# Altium schematic sheet Sheet1.SchDoc
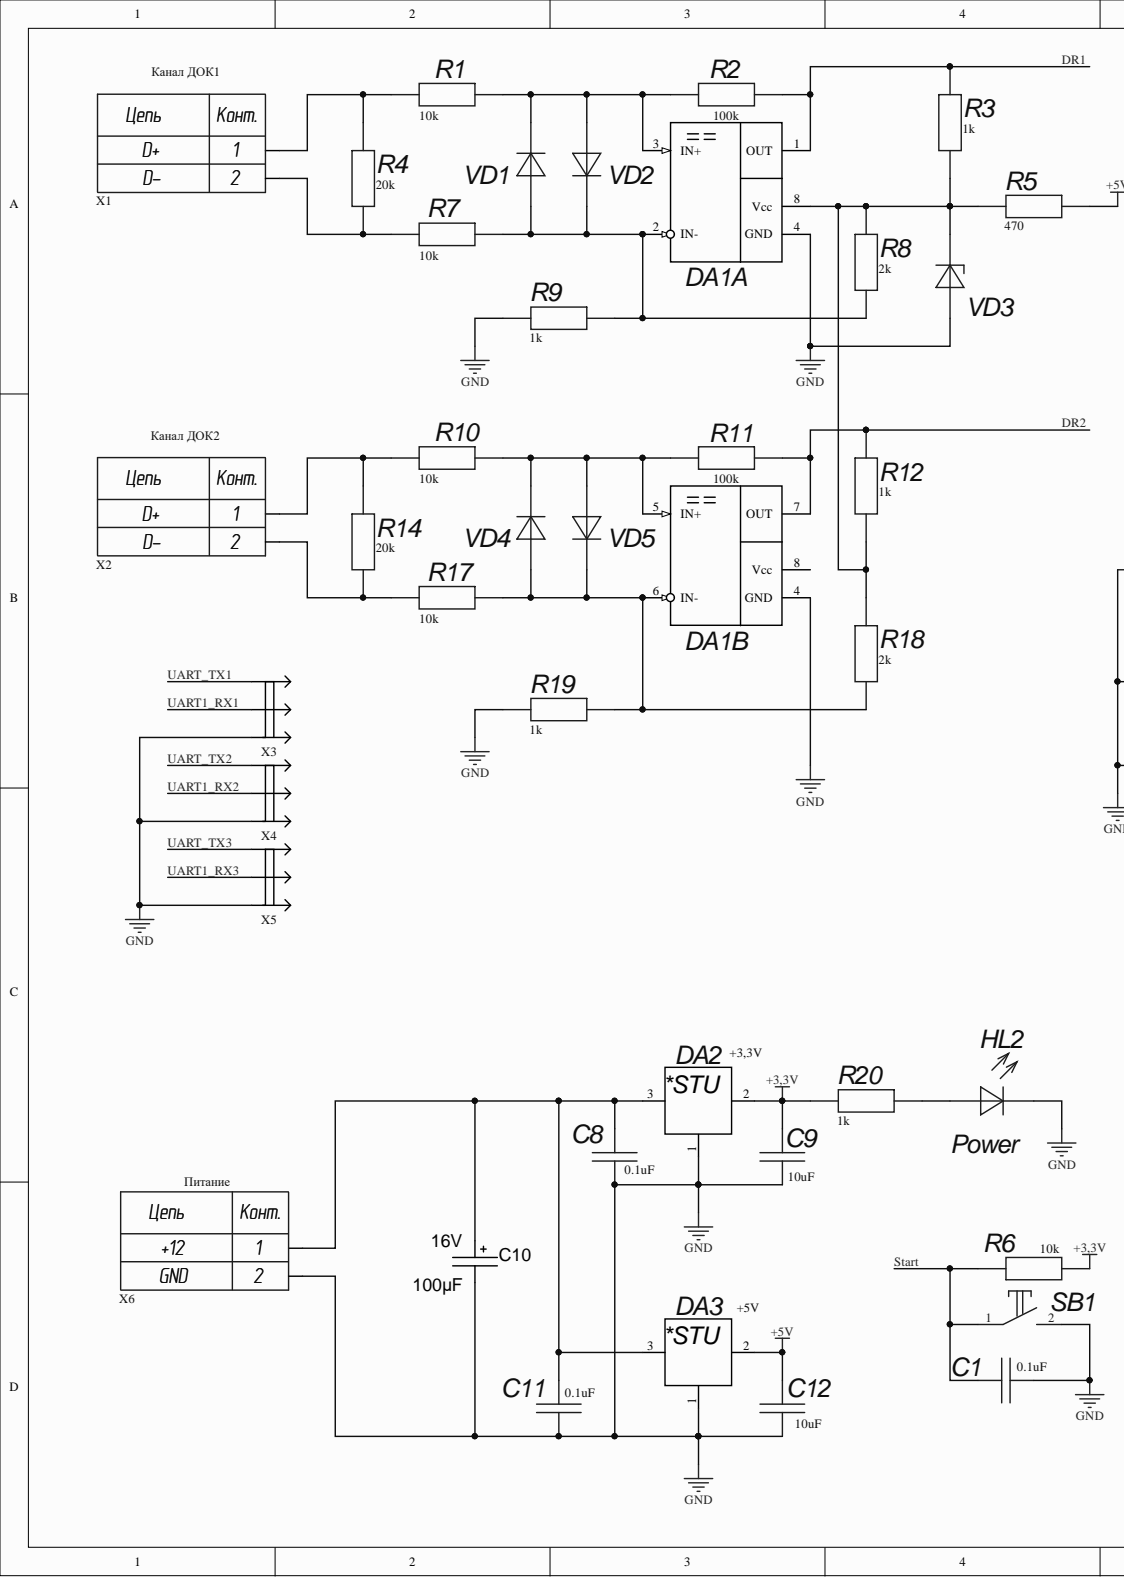
[diagram p0: Sheet1.SchDoc, left]
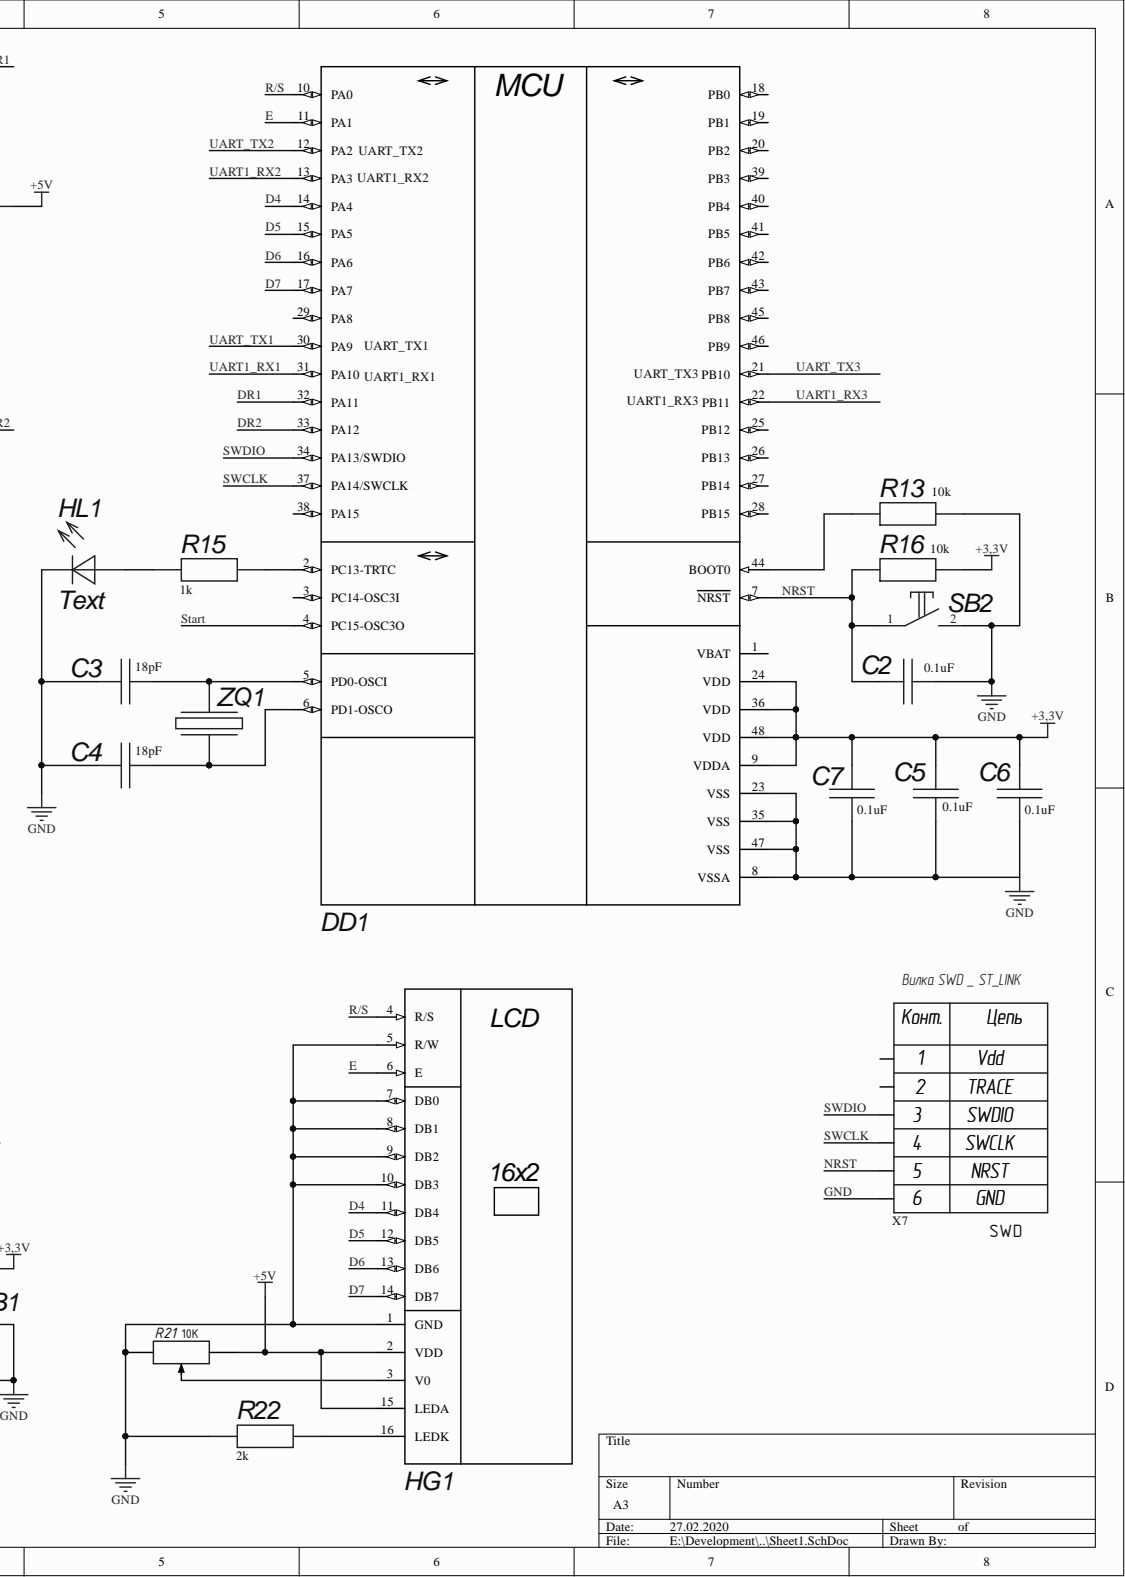
[diagram p1: Sheet1.SchDoc, right]

## Parts
C1  value 0.1uF  [0805]  (hidden comment: Capacitor)
C2  value 0.1uF  [0805]  (hidden comment: Capacitor)
C3  value 18pF  [0603]  (hidden comment: Capacitor)
C4  value 18pF  [0603]  (hidden comment: Capacitor)
C5  value 0.1uF  [0805]  (hidden comment: Capacitor)
C6  value 0.1uF  [0805]  (hidden comment: Capacitor)
C7  value 0.1uF  [0805]  (hidden comment: Capacitor)
C8  value 0.1uF  [0805]  (hidden comment: Capacitor)
C9  value 10uF  [0805]  (hidden comment: Capacitor)
C10  value 100μF  Voltage: 16V  [CAP: D5C2H11]  (hidden comment: #NAME?)
C11  value 0.1uF  [0805]  (hidden comment: Capacitor)
C12  value 10uF  [0805]  (hidden comment: Capacitor)
DA1  COMP_2x_8p  [SOIC-8]  (value not drawn)  (parts A,B)
DA2  Output Voltage: +3,3V  [SOT-223]  (hidden comment: LM1117-Series)
DA3  Output Voltage: +5V  [SOT-223]  (hidden comment: LM1117-Series)
DD1  STM32F103Cxxx-Series  [LQFP-48]  (value not drawn)
HG1  QC1602AV2_0  [QC1602AV2_0 _PLS]  (value not drawn)
HL1  Text  [3mm]
HL2  Power  [3mm]
R1  value 10k  [0805]  (hidden comment: Resistor)
R2  value 100k  [0805]  (hidden comment: Resistor)
R3  value 1k  [0805]  (hidden comment: Resistor)
R4  value 20k  [0603]  (hidden comment: Resistor)
R5  value 470  [0805]  (hidden comment: Resistor)
R6  value 10k  [0805]  (hidden comment: Resistor)
R7  value 10k  [0805]  (hidden comment: Resistor)
R8  value 2k  [0805]  (hidden comment: Resistor)
R9  value 1k  [0805]  (hidden comment: Resistor)
R10  value 10k  [0805]  (hidden comment: Resistor)
R11  value 100k  [0805]  (hidden comment: Resistor)
R12  value 1k  [0805]  (hidden comment: Resistor)
R13  value 10k  [0805]  (hidden comment: Resistor)
R14  value 20k  [0603]  (hidden comment: Resistor)
R15  value 1k  [0805]  (hidden comment: Resistor)
R16  value 10k  [0805]  (hidden comment: Resistor)
R17  value 10k  [0805]  (hidden comment: Resistor)
R18  value 2k  [0805]  (hidden comment: Resistor)
R19  value 1k  [0805]  (hidden comment: Resistor)
R20  value 1k  [0805]  (hidden comment: Resistor)
R21  value 10К  [CA9V]  (hidden comment: Potentiometer)
R22  value 2k  [0805]  (hidden comment: Resistor)
SB1  Text  [TS-A3PS]  (value not drawn)
SB2  Text  [TS-A3PS]  (value not drawn)
VD1  Diode  [DO-214AC]  (value not drawn)
VD2  Diode  [DO-214AC]  (value not drawn)
VD3  Zener  [SOD-80]  (value not drawn)
VD4  Diode  [DO-214AC]  (value not drawn)
VD5  Diode  [DO-214AC]  (value not drawn)
X1  Канал ДОК1  [2EDGV-5.0-02P-14]
X2  Канал ДОК2  [2EDGV-5.0-02P-14]
X3  Разъем PLS-3  [HDR1X3]  (value not drawn)
X4  Разъем PLS-3  [HDR1X3]  (value not drawn)
X5  Разъем PLS-3  [HDR1X3]  (value not drawn)
X6  Питание  [2EDGV-5.0-02P-14]
X7  Вилка SWD _ ST_LINK  value SWD  [PLD6]
ZQ1  Crystal  [HC-49SM]  (value not drawn)

## Nets
+3,3V: C5.2 C6.2 C7.2 C9.2 DA2.2 DD1.9(VDDA) DD1.24(VDD) DD1.36(VDD) DD1.48(VDD) R6.1 R16.1 R20.1
+5V: C12.2 DA3.2 HG1.2(VDD) HG1.15(LEDA) R5.2 R21.1
D4: DD1.14(PA4) HG1.11(DB4)
D5: DD1.15(PA5) HG1.12(DB5)
D6: DD1.16(PA6) HG1.13(DB6)
D7: DD1.17(PA7) HG1.14(DB7)
DR1: DA1.1(OUT) DD1.32(PA11) R2.2 R3.2
DR2: DA1.7(OUT) DD1.33(PA12) R11.2 R12.2
E: DD1.11(PA1) HG1.6(E)
GND: C1.1 C2.1 C3.1 C4.1 C5.1 C6.1 C7.1 C8.1 C9.1 C10.2 C11.1 C12.1 DA1.4(GND) DA2.1 DA3.1 DD1.8(VSSA) DD1.23(VSS) DD1.35(VSS) DD1.47(VSS) HG1.1(GND) HG1.5(R/W) HG1.7(DB0) HG1.8(DB1) HG1.9(DB2) HG1.10(DB3) HL1.2 HL2.2 R9.1 R13.1 R19.1 R21.2 R22.2 SB1.2 SB2.2 VD3.1 X3.1 X4.1 X5.1 X6.2 X7.6
NRST: C2.2 DD1.7(NRST) R16.2 SB2.1 X7.5
R/S: DD1.10(PA0) HG1.4(R/S)
Start: C1.2 DD1.4(PC15-OSC3O) R6.2 SB1.1
SWCLK: DD1.37(PA14/SWCLK) X7.4
SWDIO: DD1.34(PA13/SWDIO) X7.3
UART1_RX1: DD1.31(PA10) X3.2
UART1_RX2: DD1.13(PA3_UART1_RX2) X4.2
UART1_RX3: DD1.22(UART1_RX3_PB11) X5.2
UART_TX1: DD1.30(PA9) X3.3
UART_TX2: DD1.12(PA2) X4.3
UART_TX3: DD1.21(UART_TX3_PB10) X5.3
NetC3_2: C3.2 DD1.5(PD0-OSCI) ZQ1.3
NetC4_2: C4.2 DD1.6(PD1-OSCO) ZQ1.1
NetC8_2: C8.2 C10.1 C11.2 DA2.3 DA3.3 X6.1
NetDA1_2: DA1.2(IN-) R7.2 R8.1 R9.2 VD1.1 VD2.2
NetDA1_3: DA1.3(IN+) R1.2 R2.1 VD1.2 VD2.1
NetDA1_5: DA1.5(IN+) R10.2 R11.1 VD4.2 VD5.1
NetDA1_6: DA1.6(IN-) R17.2 R18.1 R19.2 VD4.1 VD5.2
NetDA1_8: DA1.8(Vcc) R3.1 R5.1 R8.2 R12.1 R18.2 VD3.2
NetDD1_2: DD1.2(PC13-TRTC) R15.1
NetDD1_44: DD1.44(BOOT0) R13.2
NetHG1_3: HG1.3(V0) R21.3
NetHG1_16: HG1.16(LEDK) R22.1
NetHL1_1: HL1.1 R15.2
NetHL2_1: HL2.1 R20.2
NetR1_1: R1.1 R4.2 X1.1
NetR4_1: R4.1 R7.1 X1.2
NetR10_1: R10.1 R14.2 X2.1
NetR14_1: R14.1 R17.1 X2.2

Not connected: DD1 1(VBAT) 3(PC14-OSC3I) 18(PB0) 19(PB1) 20(PB2) 25(PB12) 26(PB13) 27(PB14) 28(PB15) 29(PA8) 38(PA15) 39(PB3) 40(PB4) 41(PB5) 42(PB6) 43(PB7) 45(PB8) 46(PB9); X7 1 2

## Library data of the parts
C1 C2 C5-C8 C11 = GRM21BR71H104KA01L: Manufacturer: Murata; Application Category: 1; Database Table Name: Конденсаторы SMT - Керамические; Dielectric Type: X7R; ID: 138; Tolerance: ±10%; Type: Конденсатор; Voltage: 50V; Working Temperature Max: +125°С; Working Temperature Min: -55°С; Component Kind: Standard; Part Number: GRM21BR71H104KA01L; Published: 18.05.2010; Simulation: Capacitor; Library Name: Lib_IMP_GOST.DbLib; Publisher: Andrew Danich
C3 C4 = GRM188R71E223KA01D: Manufacturer: Murata; Application Category: 1; Database Table Name: Конденсаторы SMT - Керамические; Dielectric Type: X7R; ID: 128; Tolerance: ±10%; Type: Конденсатор; Voltage: 25V; Working Temperature Max: +125°С; Working Temperature Min: -55°С; Component Kind: Standard; Part Number: GRM188R71E223KA01D; Published: 18.05.2010; Simulation: Capacitor; Library Name: Lib_IMP_GOST.DbLib; Publisher: Andrew Danich
C9 C12 = GRM21BR61E106KA73L: Manufacturer: Murata; Database Table Name: Конденсаторы SMT - Керамические; Dielectric Type: X5R; ID: 53; Tolerance: ±10%; Type: Конденсатор; Voltage: 25V; Component Kind: Standard; Part Number: GRM21BR61E106KA73L; Published: 18.05.2010; Simulation: Capacitor; Library Name: Lib_IMP_GOST.DbLib; Publisher: Andrew Danich
C10 = Aluminium: Manufacturer: Wurth; PartNumber: 860080475013; Impedance: 54mOhm; Tolerance: ±20%; TypeCapacitor: Aluminium capacitor; Component Kind: Standard; HelpURL: https://www.digikey.com/product-detail/en/wurth-electronics-inc/860080475013/732-9029-1-ND/5728972; Library Name: Capacitor.IntLib; Package: 14x10x5 mm; Temperature: -55...+105°C; ValueManufacturerPartNumber: #NAME?
DA1 = LM293AD: Manufacturer: Texas Instruments; Application Category: 1; Channels: 2; Database Table Name: МС - Компараторы; Functional Analog: LM2903MX, LM393MX; ID: 3; Input Biasing Current: 100nA; Input Offset Voltage: ±2mV; Output Saturation Voltage: 400mV; Response Time: 1.3uS; Supply Current: 1mA; Supply Operation: +2…36V; Working Temperature Max: +85°C; Working Temperature Min: -25°C; Component Kind: Standard; Part Number: LM293AD; Type: Микросхема; Value: LM293AD; Library Name: Lib_IMP_GOST.DbLib; Publisher: Viktor Klishin
DA2 DA3 = LM1117MPX-3.3: Database Table Name: МС - Стабилизаторы Линейные; ID: 9; Input Voltage: +8.5…20V; Output Current: 800mA; Component Kind: Standard; Part Number: LM1117MPX-3.3; Published: 13.08.2015; Type: Микросхема; Value: LM1117MPX-3.3; Library Name: Lib_IMP_GOST.DbLib; Publisher: Andrew Danich
DD1 = STM32F103C8T6: Manufacturer: STMicroelectronics; Application Category: 1; Database Table Name: МС - Микроконтроллеры; Flash: 64K; I/O Lines: 37; ID: 20; Operation Frequency: 72MHz; Prog Interface: JTAG, SWD, UART; SRAM: 20K; Supply Operation: +2.0…3.6V; Working Temperature Max: +85°С; Working Temperature Min: -40°С; Component Kind: Standard; EEPROM: -; Part Number: STM32F103C8T6; Published: 16.10.2015; Type: Микросхема; Value: STM32F103C8T6; Library Name: Lib_IMP_GOST.DbLib; Publisher: Andrew Danich
HG1 = QC1602AV2_0 _PLS: Database Table Name: LCD$; ID: 1; Name: LCD16X2; ChipdipURL: https://www.chipdip.ru/product/bcb1602-03; Component Kind: Standard; ComponentLink1Description: Datashet; ComponentLink1URL: https://www.openhacks.com/uploadsproductos/eone-1602a1.pdf; Library Name: Library.DbLib; Part Number: QC1602AV2_0 _PLS; Published: 28.08.2014; Type: Индикатор графический; Value: QC1602AV2_0; Publisher: Andrew Danich
HL1 = BL-L314UGC: Application Category: 1; Color: Ultra Green; Database Table Name: Светодиоды THM - Общ; Forward Current: 30mA; Forward Voltage: 2.2V; Power Dissipation: 75mW; Type: Индикатор единичный; Component Kind: Standard; ID: 1; Leds: 1; Part Number: BL-L314UGC; Published: 03.12.2013; Value: BL-L314UGC; Library Name: Lib_IMP_GOST.DbLib; Publisher: Andrew Danich
HL2 = BL-L314HD: Application Category: 1; Color: Red; Database Table Name: Светодиоды THM - Общ; Forward Current: 25mA; Forward Voltage: 2.25V; ID: 2; Power Dissipation: 60mW; Type: Индикатор единичный; Component Kind: Standard; Leds: 1; Part Number: BL-L314HD; Published: 03.12.2013; Value: BL-L314HD; Library Name: Lib_IMP_GOST.DbLib; Publisher: Andrew Danich
R1 R6 R7 R10 R13 R16 R17 = RC0805JR-0710KL: Manufacturer: Yageo; Application Category: 1; Database Table Name: Резисторы SMT - Постоянные; ID: 99; Limit Voltage: 150V; Series Type: Thick-Film; Temperature Coefficient: 100ppm; Tolerance: ±5%; Total Power Dissipation: 0.125W; Working Temperature Max: +155°С; Working Temperature Min: -55°С; Component Kind: Standard; Part Number: RC0805JR-0710KL; Published: 24.03.2010; Simulation: Resistor; Type: Резистор; Library Name: Lib_IMP_GOST.DbLib; Publisher: Andrew Danich
R2 = RC0805JR-0733KL: Manufacturer: Yageo; Application Category: 1; Database Table Name: Резисторы SMT - Постоянные; ID: 136; Limit Voltage: 150V; Series Type: Thick-Film; Temperature Coefficient: 100ppm; Tolerance: ±5%; Total Power Dissipation: 0.125W; Value: 100k; Working Temperature Max: +155°С; Working Temperature Min: -55°С; Component Kind: Standard; Part Number: RC0805JR-0733KL; Published: 24.03.2010; Simulation: Resistor; Type: Резистор; Library Name: Lib_IMP_GOST.DbLib; Publisher: Andrew Danich
R3 R9 R12 R15 R19 R20 = RC0805JR-071KL: Manufacturer: Yageo; Application Category: 1; Database Table Name: Резисторы SMT - Постоянные; ID: 112; Limit Voltage: 150V; Series Type: Thick-Film; Temperature Coefficient: 100ppm; Tolerance: ±5%; Total Power Dissipation: 0.125W; Working Temperature Max: +155°С; Working Temperature Min: -55°С; Component Kind: Standard; Part Number: RC0805JR-071KL; Published: 24.03.2010; Simulation: Resistor; Type: Резистор; Library Name: Lib_IMP_GOST.DbLib; Publisher: Andrew Danich
R4 R14 = RC0603FR-0720KL: Manufacturer: Yageo; Application Category: 1; Database Table Name: Резисторы SMT - Постоянные; ID: 259; Limit Voltage: 50V; Series Type: Thick-Film; Temperature Coefficient: 100ppm; Tolerance: ±1%; Total Power Dissipation: 0.1W; Working Temperature Max: +155°С; Working Temperature Min: -55°С; Component Kind: Standard; Part Number: RC0603FR-0720KL; Published: 24.03.2010; Simulation: Resistor; Type: Резистор; Library Name: Lib_IMP_GOST.DbLib; Publisher: Andrew Danich
R5 = RC0805JR-07470RL: Manufacturer: Yageo; Application Category: 1; Database Table Name: Резисторы SMT - Постоянные; ID: 144; Limit Voltage: 150V; Series Type: Thick-Film; Temperature Coefficient: 100ppm; Tolerance: ±5%; Total Power Dissipation: 0.125W; Working Temperature Max: +155°С; Working Temperature Min: -55°С; Component Kind: Standard; Part Number: RC0805JR-07470RL; Published: 24.03.2010; Simulation: Resistor; Type: Резистор; Library Name: Lib_IMP_GOST.DbLib; Publisher: Andrew Danich
R8 R18 R22 = RC0805JR-072KL: Manufacturer: Yageo; Application Category: 1; Database Table Name: Резисторы SMT - Постоянные; ID: 129; Limit Voltage: 150V; Series Type: Thick-Film; Temperature Coefficient: 100ppm; Tolerance: ±5%; Total Power Dissipation: 0.125W; Working Temperature Max: +155°С; Working Temperature Min: -55°С; Component Kind: Standard; Part Number: RC0805JR-072KL; Published: 24.03.2010; Simulation: Resistor; Type: Резистор; Library Name: Lib_IMP_GOST.DbLib; Publisher: Andrew Danich
R11 = RC0805JR-0733KL: Manufacturer: Yageo; Application Category: 1; Database Table Name: Резисторы SMT - Постоянные; ID: 136; Limit Voltage: 150V; Series Type: Thick-Film; Temperature Coefficient: 100ppm; Tolerance: ±5%; Total Power Dissipation: 0.125W; Working Temperature Max: +155°С; Working Temperature Min: -55°С; Component Kind: Standard; Part Number: RC0805JR-0733KL; Published: 24.03.2010; Simulation: Resistor; Type: Резистор; Library Name: Lib_IMP_GOST.DbLib; Publisher: Andrew Danich
R21 = CA9PV10-10KA2020: Database Table Name: Ressistors$; ID: 6; Name: Resistor; Nominal value: 10; SortUnit: 2; Units: К; ChipdipURL: https://www.chipdip.ru/product0/9000079478; Component Kind: Standard; ComponentLink1Description: Datashet; ComponentLink1URL: https://static.chipdip.ru/lib/250/DOC000250611.pdf; Library Name: Library.DbLib; Part Number: CA9PV10-10KA2020; Type: Резистор
SB1 SB2 = TS-A3PS-130: Application Category: 1; Backlight: NO; Database Table Name: Переключатели - Кнопочные; ID: 24; Isolator Resistance: 100meg; Limit Current: 50mA; Limit Voltage: 250V; Number of Contacts: 4; Resistance: 0.1ohm; Component Kind: Standard; Height: 7mm; Part Number: TS-A3PS-130; Published: 17.04.2014; Type: Переключатель; Value: TS-A3PS-130; Library Name: Lib_IMP_GOST.DbLib; Publisher: Andrew Danich
VD1 VD2 VD4 VD5 = ES1G: Capacitance: 10pF; Database Table Name: Диоды SMT - Общ; Forward Current: 1A; Forward Voltage: 1.3V; ID: 3; Recovery Time: 35nS; Reverse Current: 5uA; Reverse Voltage: 400V; Total Power Dissipation: 1.47W; Type: Диод; Value: ES1F; Component Kind: Standard; Part Number: ES1G; Published: 18.05.2010; Library Name: Lib_IMP_GOST.DbLib; Publisher: Andrew Danich
VD3 = BZV55-C5V1: Capacitance: 300pF; Database Table Name: Диоды SMT - Стабилитроны; Differential resistance: 60ohm; Forward Current: 250mA; Forward Voltage: 0.9V; ID: 1; Reverse Current: 2uA; Total Power Dissipation: 0.5W; Type: Стабилитрон; Working Voltage: 5.1V; Component Kind: Standard; Part Number: BZV55-C5V1; Published: 20.05.2013; Value: BZV55-C5V1; Library Name: Lib_IMP_GOST.DbLib; Publisher: Andrew Danich
X1 X2 X6 = Вилка 2EDGV-5.08-02P: Component Kind: Standard; Library Name: Connector PCB.IntLib
X3-X5 = Вилка 3pin: Вилка штыревая 2.54мм 1x3 прямая в отверстия; Component Kind: Standard; Library Name: Connector PCB.IntLib
X7 = Вилка SWD _ ST_LINK: Вилка 2x3 pin 2.54 mm в отверстия; Component Kind: Standard; Library Name: Connector PCB.IntLib
ZQ1 = HC49SM-8.000MHz-20pF: Application Category: 1; Database Table Name: Кварцевые Резонаторы SMT; ID: 17; Load Capacitance: 20pF; Output Frequency: 8MHz; Temperature Coefficient: ±50ppm; Value: HC49S-8.000MHZ-20pF; Component Kind: Standard; Part Number: HC49SM-8.000MHz-20pF; Published: 08.03.2013; Type: Резонатор; Library Name: Lib_IMP_GOST.DbLib; Publisher: Andrew Danich
